# Altium schematic sheet PiPico_Pinout_Schematic.SchDoc(PiPico_Pinout_Schematic)
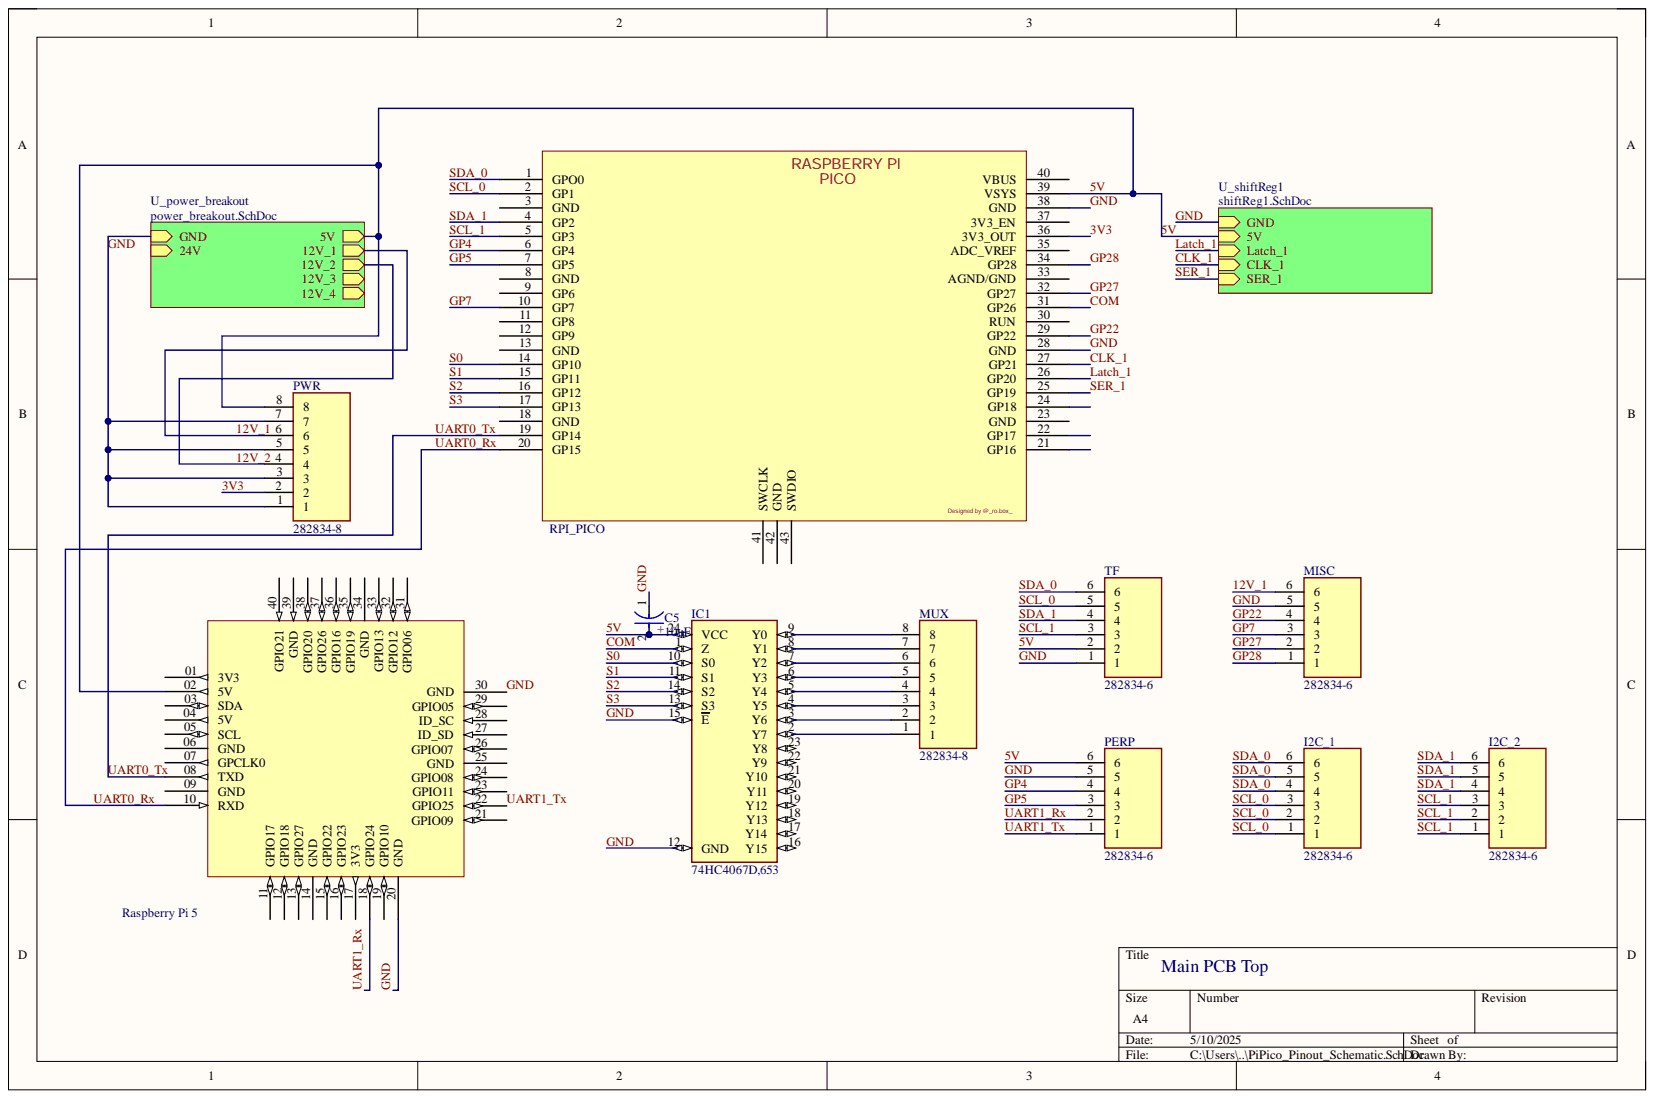
[diagram p0: PiPico_Pinout_Schematic.SchDoc]

## Parts
C5  10uF  [FP-TAP106K016SCS-MFG]
I2C_1  282834-6  [TE_282834-6]
I2C_2  282834-6  [TE_282834-6]
IC1  74HC4067D,653  [SOIC127P1032X265-24N]
MISC  282834-6  [TE_282834-6]
MUX  282834-8  [TE_282834-8]
PERP  282834-6  [TE_282834-6]
PWR  282834-8  [TE_282834-8]
RPI_PICO  RPI_PICO  [RPI PICO 2020]
TF  282834-6  [TE_282834-6]
  Raspberry Pi 5  [SAMTEC_ESQ-120-24-L-D-034]

## Nets
Net_01: .01(3V3)
Net_03: .03(SDA)
Net_04: .04(5V)
Net_05: .05(SCL)
Net_06: .06(GND)
Net_07: .07(GPCLK0)
Net_09: .09(GND)
Net_11: .11(GPIO17)
Net_12: .12(GPIO18)
Net_13: .13(GPIO27)
Net_14: .14(GND)
Net_15: .15(GPIO22)
Net_16: .16(GPIO23)
Net_17: .17(3V3)
Net_19: .19(GPIO10)
Net_21: .21(GPIO09)
Net_23: .23(GPIO11)
Net_24: .24(GPIO08)
Net_25: .25(GND)
Net_26: .26(GPIO07)
Net_27: .27(ID_SD)
Net_28: .28(ID_SC)
Net_29: .29(GPIO05)
Net_31: .31(GPIO06)
Net_32: .32(GPIO12)
Net_33: .33(GPIO13)
Net_34: .34(GND)
Net_35: .35(GPIO19)
Net_36: .36(GPIO16)
Net_37: .37(GPIO26)
Net_38: .38(GPIO20)
Net_39: .39(GND)
Net_40: .40(GPIO21)
3V3_2: PWR.2 RPI_PICO.36(3V3_OUT)  [also labelled 3V3]
5V_2: C5.2 IC1.24(VCC) PERP.6 PWR.8 RPI_PICO.39(VSYS) TF.2 .02(5V)  [also labelled 5V]
12V_1_2: MISC.6 PWR.6  [also labelled 12V_1]
12V_2_2: PWR.4  [also labelled 12V_2]
CLK_1_2: RPI_PICO.27(GP21)  [also labelled CLK_1]
COM_2: IC1.1(Z) RPI_PICO.31(GP26)  [also labelled COM]
GND_2: C5.1 IC1.12(GND) IC1.15(E) MISC.5 PERP.5 PWR.1 PWR.3 PWR.5 PWR.7 RPI_PICO.28(GND) RPI_PICO.38(GND) TF.1 .20(GND) .30(GND)  [also labelled GND]
GP4_2: PERP.4 RPI_PICO.6(GP4)  [also labelled GP4]
GP5_2: PERP.3 RPI_PICO.7(GP5)  [also labelled GP5]
GP7_2: MISC.3 RPI_PICO.10(GP7)  [also labelled GP7]
GP22_2: MISC.4 RPI_PICO.29(GP22)  [also labelled GP22]
GP27_2: MISC.2 RPI_PICO.32(GP27)  [also labelled GP27]
GP28_2: MISC.1 RPI_PICO.34(GP28)  [also labelled GP28]
Latch_1_2: RPI_PICO.26(GP20)  [also labelled Latch_1]
S0_2: IC1.10(S0) RPI_PICO.14(GP10)  [also labelled S0]
S1_2: IC1.11(S1) RPI_PICO.15(GP11)  [also labelled S1]
S2_2: IC1.14(S2) RPI_PICO.16(GP12)  [also labelled S2]
S3_2: IC1.13(S3) RPI_PICO.17(GP13)  [also labelled S3]
SCL_0_2: I2C_1.1 I2C_1.2 I2C_1.3 RPI_PICO.2(GP1) TF.5  [also labelled SCL_0]
SCL_1_2: I2C_2.1 I2C_2.2 I2C_2.3 RPI_PICO.5(GP3) TF.3  [also labelled SCL_1]
SDA_0_2: I2C_1.4 I2C_1.5 I2C_1.6 RPI_PICO.1(GPO0) TF.6  [also labelled SDA_0]
SDA_1_2: I2C_2.4 I2C_2.5 I2C_2.6 RPI_PICO.4(GP2) TF.4  [also labelled SDA_1]
SER_1_2: RPI_PICO.25(GP19)  [also labelled SER_1]
UART0_Rx_2: RPI_PICO.20(GP15) .10(RXD)  [also labelled UART0_Rx]
UART0_Tx_2: RPI_PICO.19(GP14) .08(TXD)  [also labelled UART0_Tx]
UART1_Rx_2: PERP.2 .18(GPIO24)  [also labelled UART1_Rx]
UART1_Tx_2: PERP.1 .22(GPIO25)  [also labelled UART1_Tx]
NetIC1_2: IC1.2(Y7) MUX.1
NetIC1_3: IC1.3(Y6) MUX.2
NetIC1_4: IC1.4(Y5) MUX.3
NetIC1_5: IC1.5(Y4) MUX.4
NetIC1_6: IC1.6(Y3) MUX.5
NetIC1_7: IC1.7(Y2) MUX.6
NetIC1_8: IC1.8(Y1) MUX.7
NetIC1_9: IC1.9(Y0) MUX.8
NetIC1_16: IC1.16(Y15)
NetIC1_17: IC1.17(Y14)
NetIC1_18: IC1.18(Y13)
NetIC1_19: IC1.19(Y12)
NetIC1_20: IC1.20(Y11)
NetIC1_21: IC1.21(Y10)
NetIC1_22: IC1.22(Y9)
NetIC1_23: IC1.23(Y8)
NetRPI_PICO_3: RPI_PICO.3(GND)
NetRPI_PICO_8: RPI_PICO.8(GND)
NetRPI_PICO_9: RPI_PICO.9(GP6)
NetRPI_PICO_11: RPI_PICO.11(GP8)
NetRPI_PICO_12: RPI_PICO.12(GP9)
NetRPI_PICO_13: RPI_PICO.13(GND)
NetRPI_PICO_18: RPI_PICO.18(GND)
NetRPI_PICO_21: RPI_PICO.21(GP16)
NetRPI_PICO_22: RPI_PICO.22(GP17)
NetRPI_PICO_23: RPI_PICO.23(GND)
NetRPI_PICO_24: RPI_PICO.24(GP18)
NetRPI_PICO_30: RPI_PICO.30(RUN)
NetRPI_PICO_33: RPI_PICO.33(AGND/GND)
NetRPI_PICO_35: RPI_PICO.35(ADC_VREF)
NetRPI_PICO_37: RPI_PICO.37(3V3_EN)
NetRPI_PICO_40: RPI_PICO.40(VBUS)
NetRPI_PICO_41: RPI_PICO.41(SWCLK)
NetRPI_PICO_42: RPI_PICO.42(GND)
NetRPI_PICO_43: RPI_PICO.43(SWDIO)
12V_3_2:
12V_4_2:
24V_2:

## Library data of the parts
C5 = CMP-04424-000616-1: CAP TANT 10UF 10% 16V RADIAL; Dielectric Material: Tantalum; Dissipation Factor: 8%; ESR (Equivalent Series Resistance): 3.2R; Lead Diameter: 0.5mm; Leakage Current: 1.2uA; Life (Hours): 1000Hour; Polarity: Polar; Capacitance: 10uF; Case/Package: Dipped; Component Kind: Standard; Construction: RADIAL; Diameter: 5mm; Features: General Purpose; Height: 9mm; Height - Seated (Max): 0.354inch; Lead Free: Lead Free; Lead Pitch: 2.5mm; Length: 9mm; Library Name: Altium Content Vault; Max Operating Temperature: 125°C; Min Operating Temperature: -55°C; Mount: Through Hole; Number of Pins: 2; Package Quantity: 1000; Packaging: Bulk; Radiation Hardening: No; RoHS Compliant: Yes; Series: TAP; Size: 9mm; Termination: Radial; Tolerance: 10%; Voltage: 16V; Voltage Rating: 16V; Voltage Rating (DC): 16V
I2C_1 I2C_2 MISC PERP TF = 282834-6: MF: {'manufacturer': 'TE Connectivity'}; Availability: Unavailable; Component Kind: Standard; Library Name: 282834-6.SchLib; MP: 282834-6; Package: None; Price: None
IC1 = 74HC4067D,653: Analog Switch/Analog Multiplexer Single 16:1 24-Pin SO T/R; MF: Nexperia USA Inc.; Check_prices: https://www.snapeda.com/parts/74HC4067D,653/Nexperia/view-part/?ref=eda; Component Kind: Standard; Library Name: 74HC4067D,653.SchLib; MP: 74HC4067D,653; Package: SOIC-24 Nexperia USA; SnapEDA_Link: https://www.snapeda.com/parts/74HC4067D,653/Nexperia/view-part/?ref=snap
MUX PWR = 282834-8: Buchanan; Conn; Term Blk; PCB; 8; 2.54mm; Front; 30-16 AWG; Green; 10 A; 150 V; MF: TE Connectivity; Check_prices: https://www.snapeda.com/parts/282834-8/TE+Connectivity+AMP+Connectors/view-part/?ref=eda; Component Kind: Standard; Digi-Key_Part_Number: A98338-ND; Library Name: 282834-8.SchLib; MP: 282834-8; Package: None; Purchase-URL: https://pricing.snapeda.com/search/part/282834-8/?ref=eda; SnapEDA_Link: https://www.snapeda.com/parts/282834-8/TE+Connectivity+AMP+Connectors/view-part/?ref=snap
RPI_PICO = RPI_PICO: Component Kind: Standard; Library Name: RPI_PICO.IntLib
 = Raspberry Pi 5: Component Kind: Standard; Library Name: Team3.SchLib
